# Altium schematic sheet POWER.SchDoc
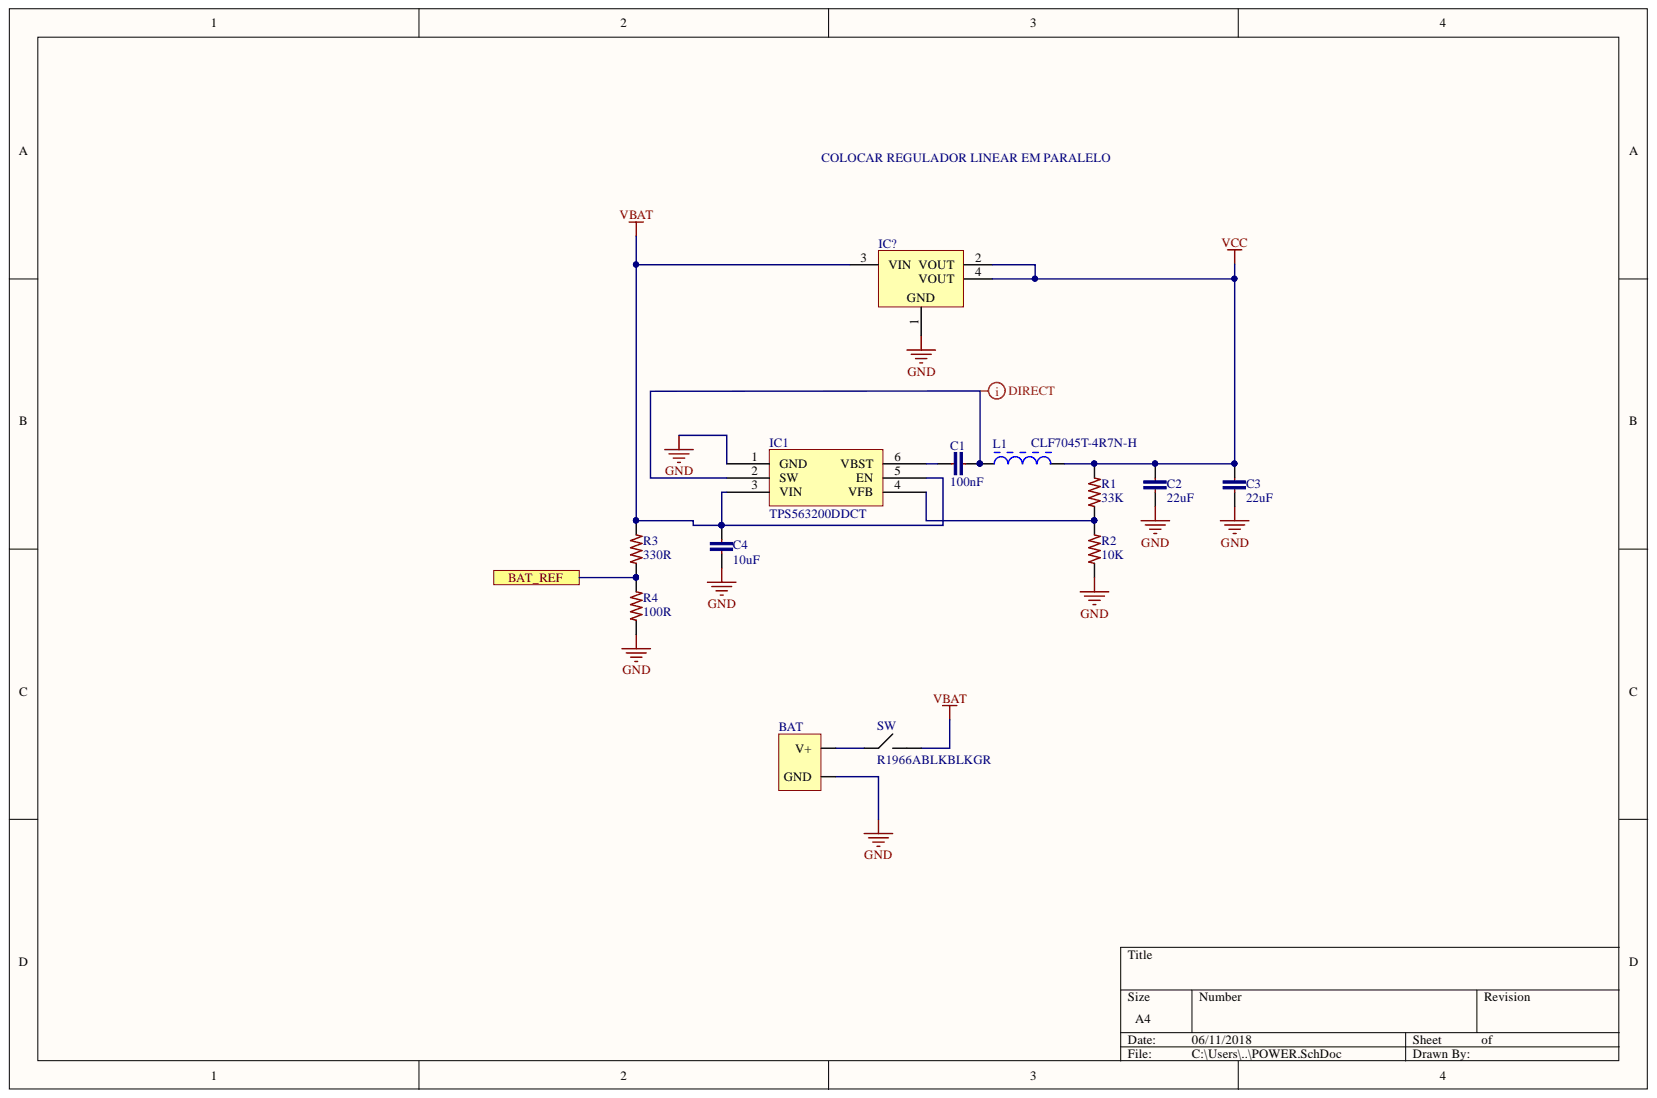
[diagram p0: POWER.SchDoc]

## Parts
BAT  XT60  [BATTERY_CONECTO-PCB]  (value not drawn)
C1  100nF  [0402-CAP]
C2  22uF  [1206-CAP]
C3  22uF  [1206-CAP]
C4  10uF  [1210-CAP]
IC1  TPS563200DDCT  [SOT95P280X110-6N]
IC?  LD1117S33CTR  [SOT-223_N]  (value not drawn)
L1  CLF7045T-4R7N-H  [CLF7045T]
R1  33K  [0402-RES-HD]
R2  10K  [0402-RES-HD]
R3  330R  [0402-RES-HD]
R4  100R  [0402-RES-HD]
SW  R1966ABLKBLKGR  [R1966AB-PCB]

## Ports
BAT_REF

## Nets
GND: BAT.1 C2.1 C3.1 C4.1 IC1.1(GND) IC?.1 R2.1 R4.1
VBAT: C4.2 IC1.3(VIN) IC1.5(EN) IC?.3(VIN) R3.2 SW.1
VCC: C2.2 C3.2 IC?.2(VOUT) IC?.4(VOUT) L1.2 R1.2
BAT_REF: R3.1 R4.2 ; port BAT_REF
NetBAT_0: BAT.0 SW.0
NetC1_1: C1.1 IC1.6(VBST)
NetC1_2: C1.2 IC1.2(SW) L1.1
NetIC1_4: IC1.4(VFB) R1.1 R2.2

## Library data of the parts
BAT = BATTERY_CONNECTOR: XT60 PRT-10474; Component Kind: Standard; Library Name: Schlib1.SchLib
C1 = CC0402-100nF-50v-A.3: CAP CER 0.1UF 50V 10% X7R 0402; Part No: C1005X7R1H104K050BB; Cod EMS: 05.281.019.021; Value: 100n; Component Kind: Standard; Simulation: Capacitor; Library Name: IMBEL
C2 C3 = CC1206-22uF-6.3V-1: CAP CER 22UF 6.3V 20% X5R 1206; Part No: C3216X5R0J226M085AC; Rule: Polygon Connect Style [Style - Direct Connect]; Value: 22u; Component Kind: Standard; Simulation: Capacitor; Library Name: IMBEL
C4 = CC1210-10uF-6: CAP CER 10UF 50V 20% X5R 1210; Part No: UMK325BJ106MM; Cod EMS: 05.281.019.522; value: 10u; Component Kind: Standard; Simulation: Capacitor; Library Name: IMBEL
IC1 = TPS563200DDCT-01.A.2: IC REG BUCK ADJ 3A SYNC 6SOT; Component Kind: Standard; Library Name: IMBEL
IC? = CMP-0244-00307-1: Fixed Low Drop Positive Voltage Regulator, 3.3V, 4-Pin SOT-223, Tape and Reel; Component Kind: Standard; ComponentLink1Description: Manufacturer URL; ComponentLink1URL: http://www.st.com; ComponentLink2Description: Datasheet; ComponentLink2URL: http://www.st.com/internet/com/TECHNICAL_RESOURCES/TECHNICAL_LITERATURE/DATASHEET/CD00000544.pdf; DatasheetVersion: 1/11/2011; Library Name: Altium Content Vault; PackageDescription: 4-Pin SOT-223 Package, 6.5 x 3.5 mm Body, 2.3 mm Pitch, 7 mm Lead Span; PackageReference: SOT-223; PackageVersion: 2010
L1 = CLF7045T-4R7N-H-2: FIXED IND 4.7UH 4.1A 20 MOHM SMD; Family Description: INDUTORES FIXOS 7,2x6,9mm TDK CLF7045T -45 A 125oC; Rule: Polygon Connect Style [Style - Direct Connect]; Component Kind: Standard; Family: CLF7045T; Part No: CLF7045T-4R7N-H; Library Name: IMBEL
R1 = R0402-33K-7: RES 33K OHM 1/10W 1% 0402 SMD; Part No: ERJ-2RKF3302X; Cod EMS: 05.280.029.535; Family Description: RESISTOR FILME ESPESSO 10R-1M / 1/10W / 1% / 0402. P/N: ERJ-2RKF....X – PANASONIC ELECTRONIC COMPONENTS.; Component Kind: Standard; Family: ERJ-2RKF....X; Simulation: SimModel1; Value: 33K; Library Name: IMBEL
R2 = R0402-10K-10: RES 10K OHM 1/10W 1% 0402 SMD; Part No: ERJ-2RKF1002X; Cod EMS: 05.280.029.525; Family Description: RESISTOR FILME ESPESSO 10R-1M / 1/10W / 1% / 0402. P/N: ERJ-2RKF....X – PANASONIC ELECTRONIC COMPONENTS.; Component Kind: Standard; Family: ERJ-2RKF....X; Simulation: SimModel1; Value: 10K; Library Name: IMBEL
R3 = R0402-10K-10: RES 330R OHM 1/10W 1% 0402 SMD; Part No: ERJ-2RKF1002X; Cod EMS: 05.280.029.525; Family Description: RESISTOR FILME ESPESSO 10R-1M / 1/10W / 1% / 0402. P/N: ERJ-2RKF....X – PANASONIC ELECTRONIC COMPONENTS.; Value: 330; Component Kind: Standard; Family: ERJ-2RKF....X; Simulation: SimModel1; Library Name: IMBEL
R4 = R0402-33K-7: RES 100R OHM 1/10W 1% 0402 SMD; Part No: ERJ-2RKF3302X; Cod EMS: 05.280.029.535; Family Description: RESISTOR FILME ESPESSO 10R-1M / 1/10W / 1% / 0402. P/N: ERJ-2RKF....X – PANASONIC ELECTRONIC COMPONENTS.; Value: 100; Component Kind: Standard; Family: ERJ-2RKF....X; Simulation: SimModel1; Library Name: IMBEL
SW = Rocker Switch: SWITCH ROCKER SPST 15A 125V; Component Kind: Standard; Library Name: Schlib1.SchLib
